# Altium schematic sheet Sheet_BEEE.SchDoc(Sheet_BEEE)
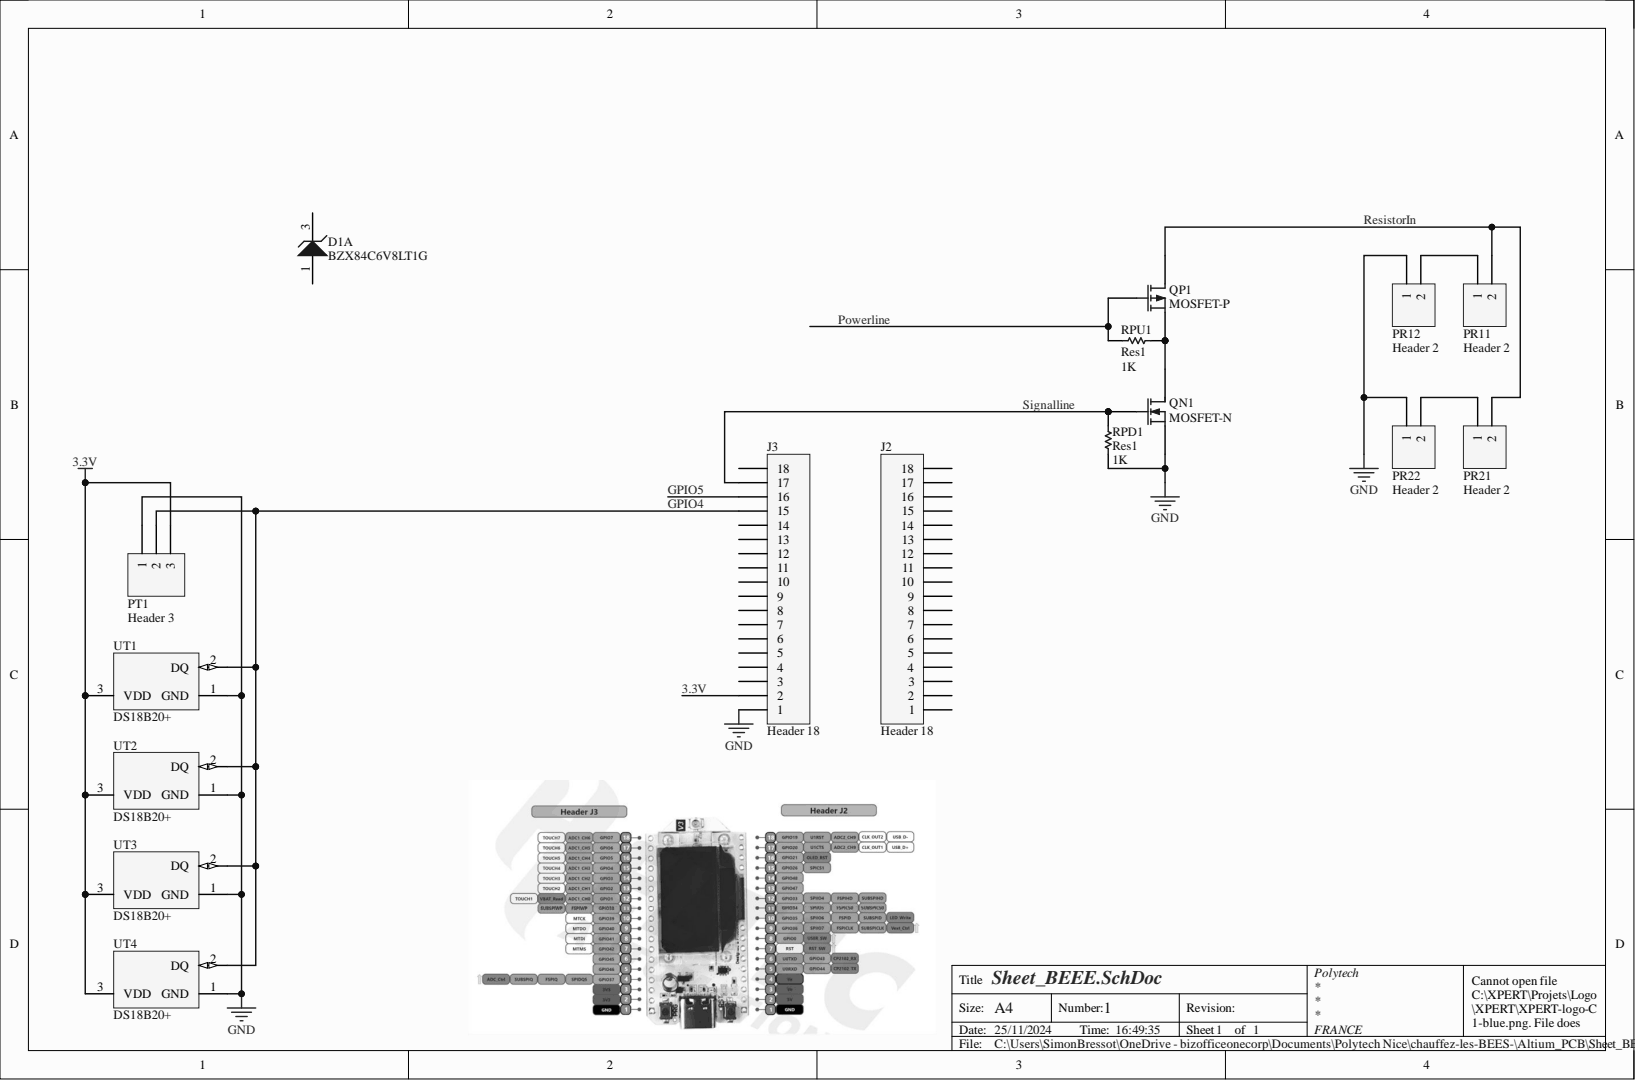
[diagram p0: Sheet_BEEE.SchDoc]

## Parts
D1  BZX84C6V8LT1G  [ONSC-SOT-23-3-318-08_V]  (part A)
J2  Header 18  [HDR1X18]
J3  Header 18  [HDR1X18]
PR11  Header 2  [HDR1X2]
PR12  Header 2  [HDR1X2]
PR21  Header 2  [HDR1X2]
PR22  Header 2  [HDR1X2]
PT1  Header 3  [HDR1X3]
QN1  MOSFET-N  [BCY-W3/B.8]
QP1  MOSFET-P  [BCY-W3/B.8]
RPD1  Res1  value 1K  [AXIAL-0.3]
RPU1  Res1  value 1K  [AXIAL-0.3]
UT1  DS18B20+  [Q3+1]
UT2  DS18B20+  [Q3+1]
UT3  DS18B20+  [Q3+1]
UT4  DS18B20+  [Q3+1]

## Nets
GND: J3.1 PR12.1 PR22.1 PT1.1 QN1.3 RPD1.1 UT1.1 UT2.1 UT3.1 UT4.1
3.3V: J3.2 PT1.3 UT1.3 UT2.3 UT3.3 UT4.3
GPIO4: J3.15 PT1.2 UT1.2 UT2.2 UT3.2 UT4.2
GPIO5: J3.16
Powerline: QP1.2 RPU1.1
ResistorIn: PR11.2 PR21.2 QP1.1
Signalline: J3.17 QN1.2 RPD1.2
NetPR11_1: PR11.1 PR12.2
NetPR21_1: PR21.1 PR22.2
NetQN1_1: QN1.1 QP1.3 RPU1.2

Not connected: D1 1 3; J2 1 2 3 4 5 6 7 8 9 10 11 12 13 14 15 16 17 18; J3 3 4 5 6 7 8 9 10 11 12 13 14 18

## Library data of the parts
D1 = CMP-1060-00902-1: Zener Voltage Regulator, 225 mW, 3-Pin SOT-23, Pb-Free, Tape and Reel; Manufacturer: On Semiconductor; Component Kind: Standard; ComponentLink1Description: Manufacturer URL; ComponentLink1URL: http://www.onsemi.com/; ComponentLink2Description: Datasheet; ComponentLink2URL: http://www.onsemi.com/pub_link/Collateral/BZX84C2V4LT1-D.PDF; ComponentLink3Description: Package Specification; ComponentLink3URL: http://www.onsemi.cn/pub_link/Collateral/318-08.PDF; DatasheetVersion: Rev. 17; Library Name: Altium Content Vault; Mounting Technology: Surface Mount; PackageDescription: 3-Pin Small Outline Transistor, Body 2.92 x 1.3 mm, Pitch 0.95 mm; PackageReference: SOT-23-3-318-08; PackageVersion: Rev. AP, 11/2009; Packing: Tape and Reel; PartNumber: BZX84C6V8LT1G; RoHS: Pb-Free
J2 J3 = Header 18: Header, 18-Pin; Component Kind: Standard; LatestRevisionDate: 17-Jul-2002; LatestRevisionNote: Re-released for DXP Platform.; Library Name: Miscellaneous Connectors.IntLib; Signal Integrity: Connector; Supplier 1: Rs; Supplier 2: Mouser; Supplier Part Number 1: 2079233; Supplier Part Number 2: 200-SSW11801TS; Publisher: Altium Limited
PR11 PR12 PR21 PR22 = Header 2: Header, 2-Pin; Component Kind: Standard; LatestRevisionDate: 17-Jul-2002; LatestRevisionNote: Re-released for DXP Platform.; Library Name: Miscellaneous Connectors.IntLib; Signal Integrity: Connector; Publisher: Altium Limited
PT1 = Header 3: Header, 3-Pin; Component Kind: Standard; LatestRevisionDate: 17-Jul-2002; LatestRevisionNote: Re-released for DXP Platform.; Library Name: Miscellaneous Connectors.IntLib; Signal Integrity: Connector; Publisher: Altium Limited
QN1 = MOSFET-N: N-Channel MOSFET; Component Kind: Standard; LatestRevisionDate: 17-Jul-2002; LatestRevisionNote: Re-released for DXP Platform.; Library Name: Miscellaneous Devices.IntLib; PackageReference: E3; PackageVersion: 1995/1996; Published: 8-Jun-2000; Simulation: NMOS; Publisher: Altium Limited
QP1 = MOSFET-P: P-Channel MOSFET; Component Kind: Standard; LatestRevisionDate: 17-Jul-2002; LatestRevisionNote: Re-released for DXP Platform.; Library Name: Miscellaneous Devices.IntLib; PackageReference: E3; PackageVersion: 1995/1996; Published: 8-Jun-2000; Simulation: PMOS; Publisher: Altium Limited
RPD1 = Res1: Resistor; Component Kind: Standard; LatestRevisionDate: 17-Jul-2002; LatestRevisionNote: Re-released for DXP Platform.; Library Name: Miscellaneous Devices.IntLib; PackageReference: AXIAL-0.3; Published: 8-Jun-2000; Signal Integrity: Res; Simulation: RESISTOR; Publisher: Altium Limited
RPU1 = Res1: Resistor; Value: 1K; Component Kind: Standard; LatestRevisionDate: 17-Jul-2002; LatestRevisionNote: Re-released for DXP Platform.; Library Name: Miscellaneous Devices.IntLib; PackageReference: AXIAL-0.3; Published: 8-Jun-2000; Signal Integrity: Res; Simulation: RESISTOR; Publisher: Altium Limited
UT1-UT4 = CMP-0253-00003-1: Programmable Resolution Digital Thermometer, 1-Wire Interface, ±0.5°C Accuracy, 3-Pin TO92, -55°C to +125°C, Pb-Free; Code_JEDEC: TO-226-AA; Component Kind: Standard; ComponentLink1Description: Manufacturer URL; ComponentLink1URL: http://www.maxim-ic.com; ComponentLink2Description: Datasheet; ComponentLink2URL: http://datasheets.maxim-ic.com/en/ds/DS18B20.pdf; DatasheetVersion: 2007; Library Name: Altium Content Vault; PackageDescription: TO-92 Package, Body Dia. 4.7mm; Leads 0.51 x 0.51 mm (max), Pb-Free; PackageReference: Q3+1; PackageVersion: revB, 2009
